# Altium schematic sheet 传感器.SchDoc(传感器)
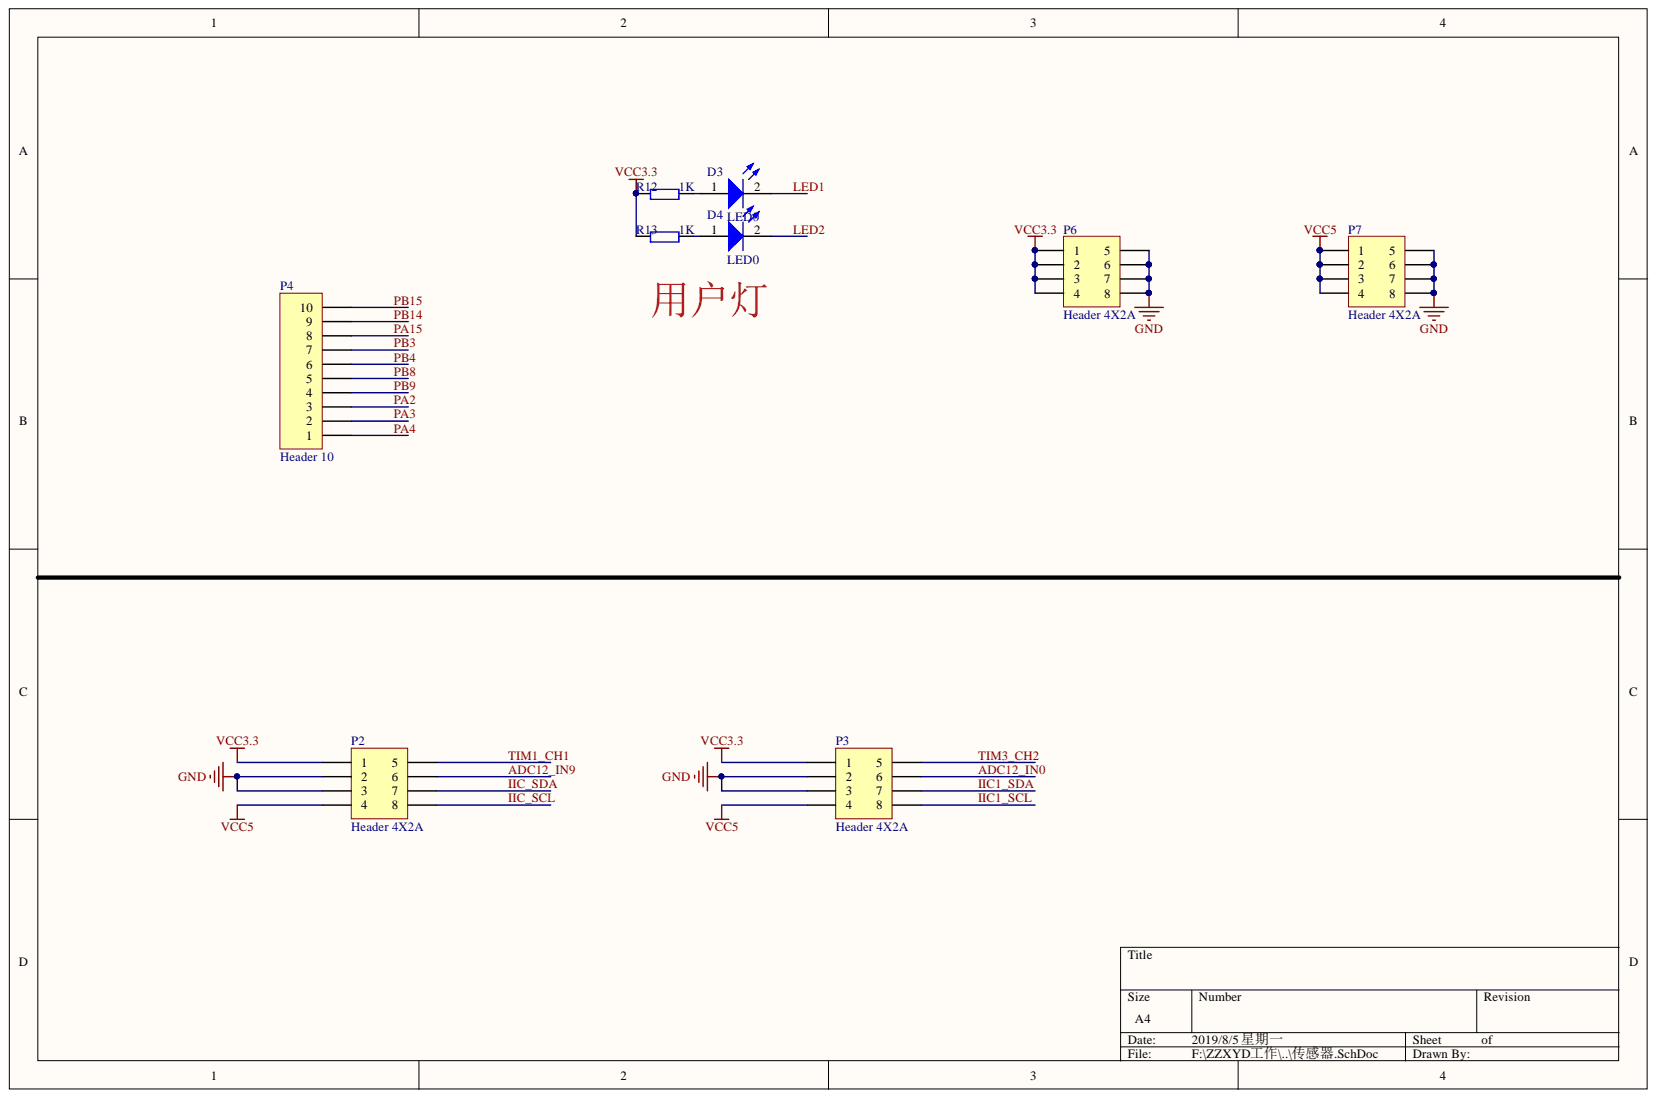
[diagram p0: 传感器.SchDoc]

## Parts
D3  LED0  [0805LED]
D4  LED0  [0805LED]
P2  Header 4X2A  [传感器接口NEW]
P3  Header 4X2A  [传感器接口NEW]
P4  Header 10  [HDR1X10]
P6  Header 4X2A  [HDR2X4_CEN]
P7  Header 4X2A  [HDR2X4_CEN]
R12  value 1K  [0805R]  (hidden comment: RES)
R13  value 1K  [0805R]  (hidden comment: RES)

## Nets
GND: P2.2 P2.3 P3.2 P3.3 P6.5 P6.6 P6.7 P6.8 P7.5 P7.6 P7.7 P7.8
VCC3.3: P2.1 P3.1 P6.1 P6.2 P6.3 P6.4 R12.1 R13.1
VCC5: P2.4 P3.4 P7.1 P7.2 P7.3 P7.4
ADC12_IN0: P3.6
ADC12_IN9: P2.6
IIC1_SCL: P3.8
IIC1_SDA: P3.7
IIC_SCL: P2.8
IIC_SDA: P2.7
LED1: D3.2
LED2: D4.2
PA2: P4.3
PA3: P4.2
PA4: P4.1
PA15: P4.8
PB3: P4.7
PB4: P4.6
PB8: P4.5
PB9: P4.4
PB14: P4.9
PB15: P4.10
TIM1_CH1: P2.5
TIM3_CH2: P3.5
NetD3_1: D3.1 R12.2
NetD4_1: D4.1 R13.2

## Library data of the parts
D3 D4 = LED0: Typical INFRARED GaAs LED; Published: 8-Jun-2000; PackageReference: LED-0; PackageDescription: LED; 2 Leads; LatestRevisionNote: Mech Layer 1 removed.; LatestRevisionDate: 08-Jul-2005; Component Kind: Standard; Publisher: Altium Limited; Library Name: 常用器件库.IntLib
P2 P3 P6 P7 = Header 4X2A: Header, 4-Pin, Dual row; Signal Integrity: Connector; Library Name: Miscellaneous Connectors.IntLib; LatestRevisionNote: Re-released for DXP Platform.; LatestRevisionDate: 17-Jul-2002; Component Kind: Standard; Publisher: Altium Limited
P4 = Header 10: Header, 10-Pin; Signal Integrity: Connector; Library Name: Miscellaneous Connectors.IntLib; LatestRevisionNote: Re-released for DXP Platform.; LatestRevisionDate: 17-Jul-2002; Component Kind: Standard; Publisher: Altium Limited
R12 R13 = R: 电阻; Library Name: WarShip V3 STM32103封装库.IntLib; Component Kind: Standard
